# Altium schematic sheet Sheet1.SchDoc(Sheet1)
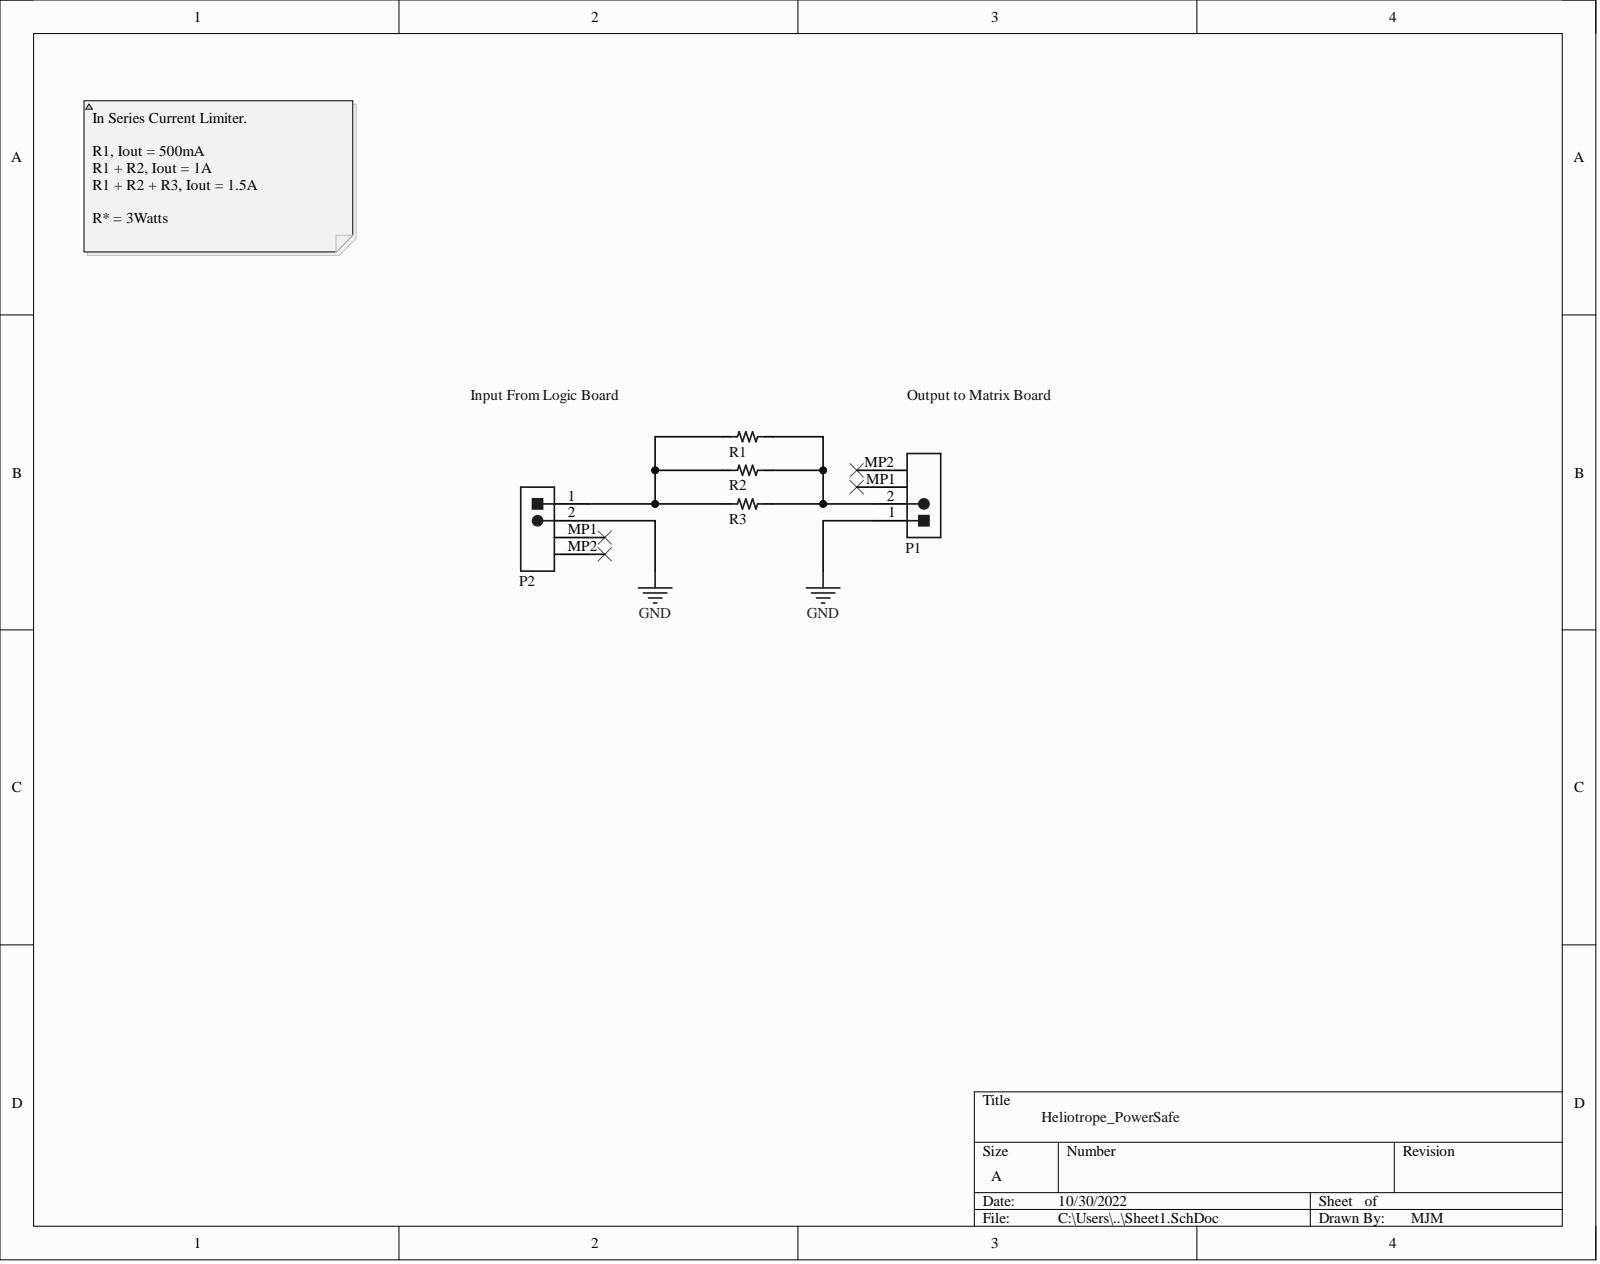
[diagram p0: Sheet1.SchDoc]

## Parts
P1  53261-0271  [MOLX-53261-0271_V]  (value not drawn)
P2  53261-0271  [MOLX-53261-0271_V]  (value not drawn)
R1  352210RFT  [RESC6331X70N]  (value not drawn)
R2  352210RFT  [RESC6331X70N]  (value not drawn)
R3  352210RFT  [RESC6331X70N]  (value not drawn)

## Nets
GND: P1.1 P2.2
NetP1_2: P1.2 R1.2 R2.2 R3.2
NetP2_1: P2.1 R1.1 R2.1 R3.1

Not connected: P1 MP1 MP2; P2 MP1 MP2

## Library data of the parts
P1 P2 = CMP-042-000002-1: 1.25mm Pitch PicoBlade™ Header, Surface Mount, Right Angle, Lead-Free, 2 Circuits; Component Kind: Standard; Library Name: Microcom Corporation
R1-R3 = CMP-146-000000-4: Res Thick Film 2512 10 Ohm 1% 3W ±100ppm/C Pad SMD T/R; Availability: Unavailable; Component Kind: Standard; Component Type: Standard; Design Item ID: 352210RFT; MP: 352210RFT; Package: None; Pin Count: 2; Price: None; Source: 2176231-1.SchLib; Library Name: Microcom Corporation
